# Altium schematic sheet Main.SchDoc(Main)
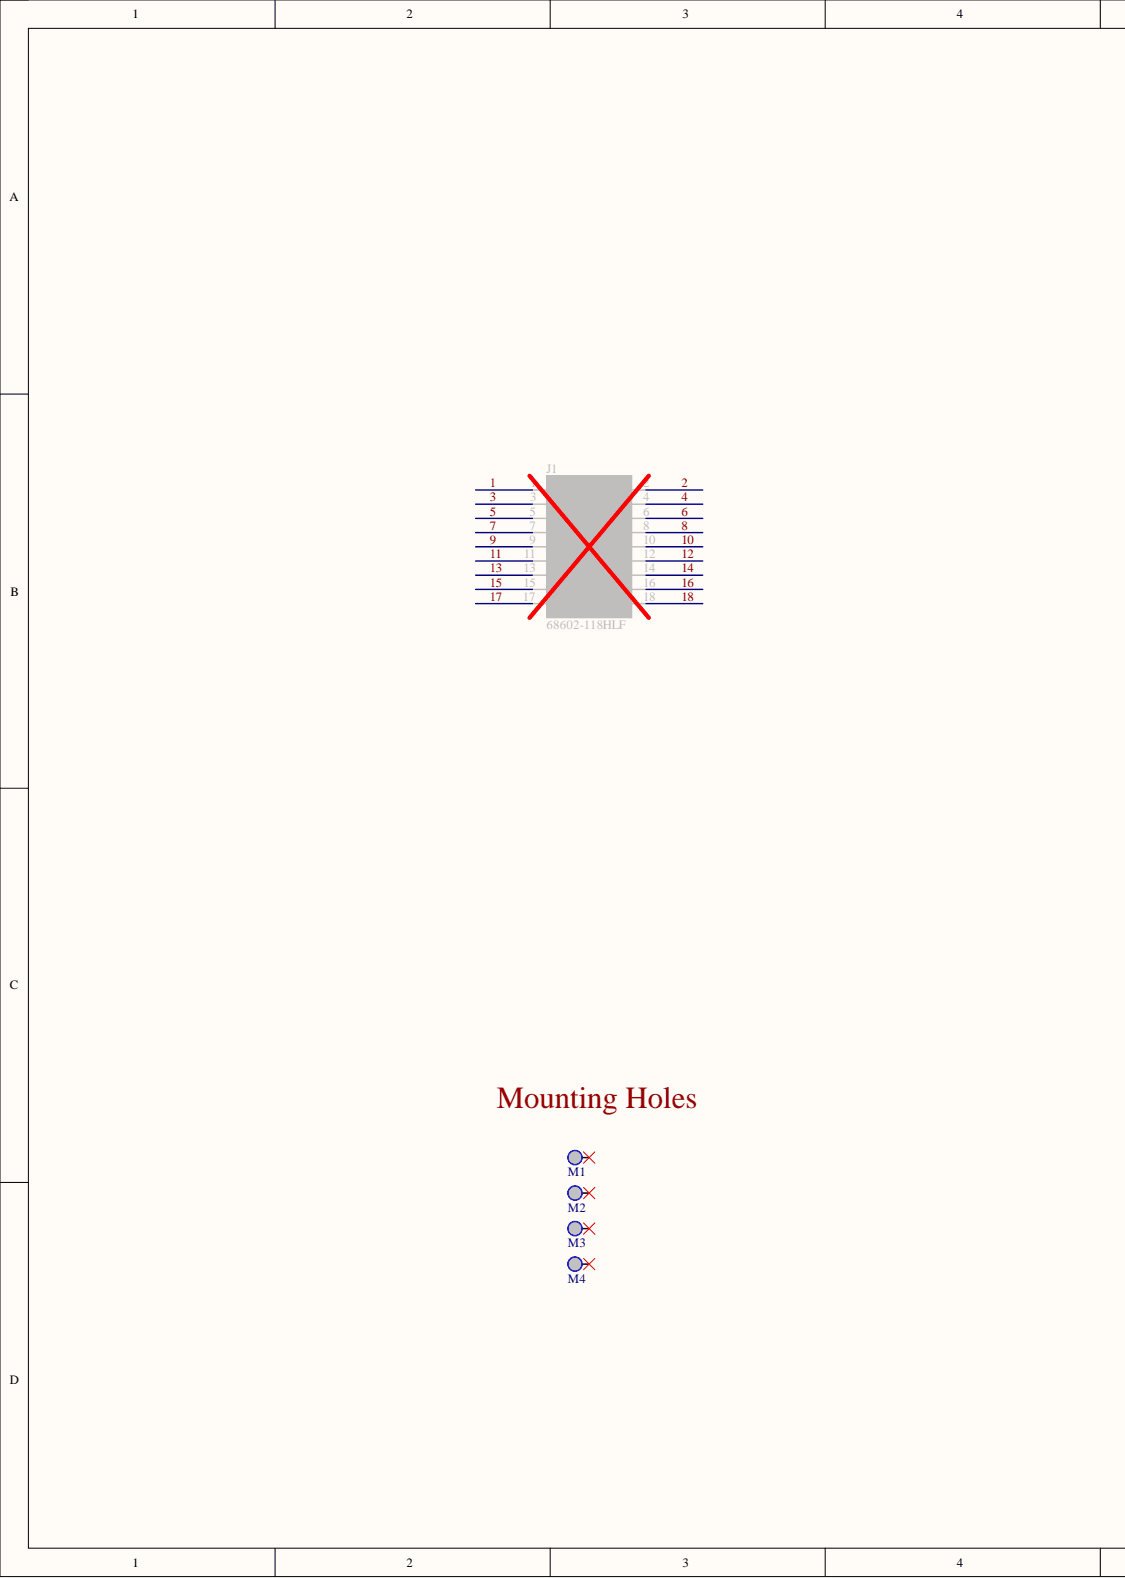
[diagram p0: Main.SchDoc, left]
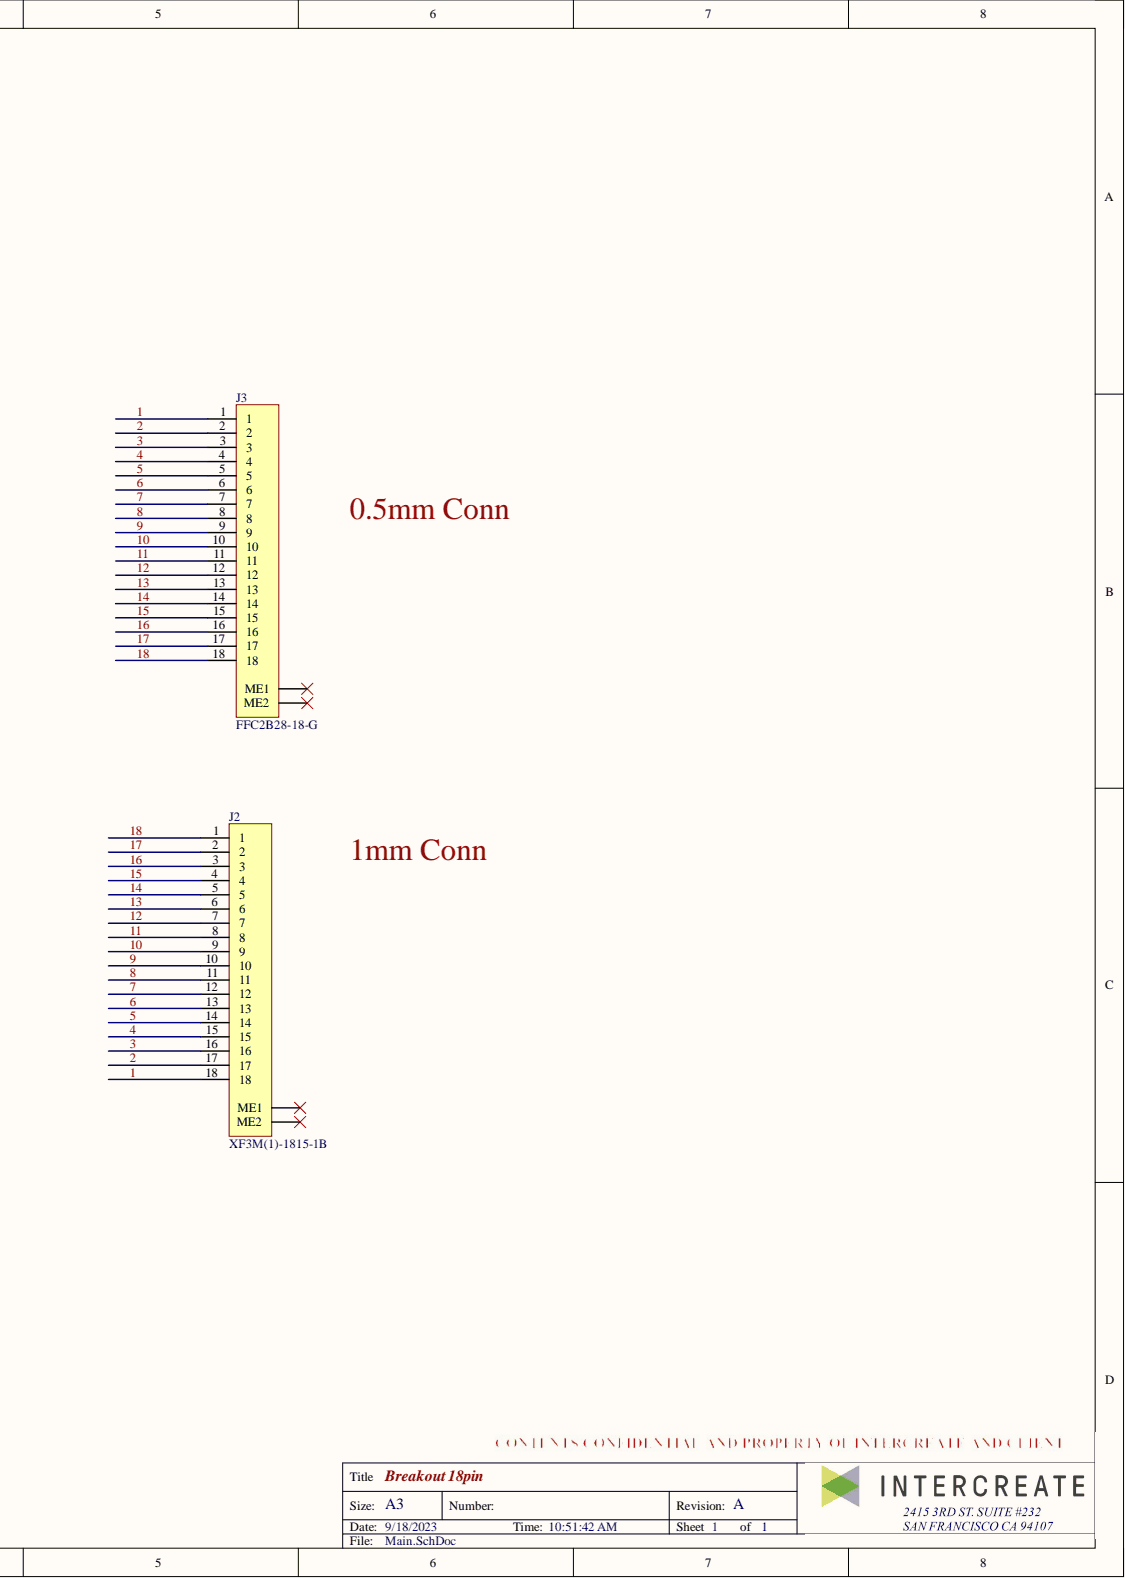
[diagram p1: Main.SchDoc, right]

## Parts
J1  68602-118HLF  [HDR-V-2X9-2.54MM]
J2  XF3M(1)-1815-1B  [CONN-FFC-H-18P-1MM]
J3  FFC2B28-18-G  [CONN-FFC-H-18P-0.5MM]
M1  MTG_HOLE  [MH_P_2.5MM]  (value not drawn)  (no BOM)
M2  MTG_HOLE  [MH_P_2.5MM]  (value not drawn)  (no BOM)
M3  MTG_HOLE  [MH_P_2.5MM]  (value not drawn)  (no BOM)
M4  MTG_HOLE  [MH_P_2.5MM]  (value not drawn)  (no BOM)

## Nets
1: J1.1 J2.18 J3.1
2: J1.2 J2.17 J3.2
3: J1.3 J2.16 J3.3
4: J1.4 J2.15 J3.4
5: J1.5 J2.14 J3.5
6: J1.6 J2.13 J3.6
7: J1.7 J2.12 J3.7
8: J1.8 J2.11 J3.8
9: J1.9 J2.10 J3.9
10: J1.10 J2.9 J3.10
11: J1.11 J2.8 J3.11
12: J1.12 J2.7 J3.12
13: J1.13 J2.6 J3.13
14: J1.14 J2.5 J3.14
15: J1.15 J2.4 J3.15
16: J1.16 J2.3 J3.16
17: J1.17 J2.2 J3.17
18: J1.18 J2.1 J3.18

Not connected: J2 ME1 ME2; J3 ME1 ME2; M1 1; M2 1; M3 1; M4 1

## Library data of the parts
J1 = HDR-V-2X9-M-2.54MM: Conn Unshrouded Header HDR 18 POS 2.54mm Solder ST Top Entry Thru-Hole BergStik® Bulk; Manufacturer 1: Amphenol ICC / FCI; Contact Post Length: 3.81mm; Current Rating: 3A; Gender: Male; Insulation Resistance: 5GR; Max Voltage Rating (AC): 1.5kV; Number of Contacts: 18; Row Spacing: 0.1inch; Category: Connectors; Color: Black; Component Kind: Standard; ComponentLink1Description: https://datasheet.ciiva.com/5168/67996-5168806.pdf?src-supplier=Mouser; ComponentLink1URL: https://datasheet.ciiva.com/5168/67996-5168806.pdf?src-supplier=Mouser; ComponentLink2Description: https://datasheet.ciiva.com/10135/67996-10135126.pdf?src-supplier=Avnet; ComponentLink2URL: https://datasheet.ciiva.com/10135/67996-10135126.pdf?src-supplier=Avnet; Contact Gender: Male; Contact Material: Bronze; Contact Mating Length: 0.23inch; Contact Plating: Gold,GXT; Contact Type: Male Pin; Depth: 4.83mm; Flammability Rating: UL94 V-0; Housing Color: Black; Housing Material: Thermoplastic; Length: 22.86mm; Manufacturer Part Number 1: 68602-118HLF; Max Operating Temperature: 125°C; Min Operating Temperature: -65°C; Mounting Technology: Through Hole; Number of Positions: 18; Number of Rows: 2; Orientation: Straight; Package Quantity: 400; Packaging: Bulk; Pitch: 2.54mm; Pricing: 1=0.1296 (USD); Radiation Hardening: No; RoHS: Yes; Series: BERGSTIK® II, MezzSelect™, Basics+; Stock: 380; Supplier: Arrow Electronics; Supplier Part Number: 68602-118HLF; Termination: Solder; Voltage Rating: 1.5kV; Library Name: intercreate.IntLib
J2 = CONN-FFC-H-18P-1MM: FFC & FPC Connectors RotaryBackLock 1.0mm Dual Contact 18pin; Manufacturer 1: Omron; Actuator Color: Black; Actuator Material: Polymer,Liquid Crystal Polymer; Contact Resistance: 50mR; Current Rating: 500mA; Insulation Resistance: 100MR; Mating Cycles: 20; Max Voltage Rating (DC): 5.05kV; Number of Contacts: 18; Pitch: 1mm; Voltage Rating: 50V; Category: FFC / FPC; Component Kind: Standard; ComponentLink1Description: https://datasheet.ciiva.com/29758/xf3m-0813-29758486.pdf?src-supplier=Digi-Key; ComponentLink1URL: https://datasheet.ciiva.com/29758/xf3m-0813-29758486.pdf?src-supplier=Digi-Key; ComponentLink2Description: https://datasheet.ciiva.com/29758/xf3m-0813-29758490.pdf?src-supplier=Digi-Key; ComponentLink2URL: https://datasheet.ciiva.com/29758/xf3m-0813-29758490.pdf?src-supplier=Digi-Key; Contact Location: Dual; Contact Material: Copper Alloy; Contact Plating: Gold; Flammability Rating: UL94 V-0; Housing Color: Natural; Housing Material: Polymer; Manufacturer Part Number 1: XF3M(1)-1815-1B; Max Current Rating: 500mA; Max Operating Temperature: 85°C; Min Operating Temperature: -30°C; Mounting Technology: Surface Mount; Number of Positions: 18; Number of Rows: 1; Orientation: Right Angle; Package Quantity: 1500; Packaging: Tape and Reel; Pricing: 1500=1.15 (USD); RoHS: Yes; RoHS Compliant: Yes; Series: XF3M; Stock: 740; Supplier: Newark; Supplier Part Number: 40AJ2874; Termination: Solder; Library Name: intercreate.IntLib
J3 = CONN-FFC-H-18P-0.5MM: 18W, 0.5MM Ffc Con, R/a, Dual Cont,; Manufacturer 1: Global Connector Technology; Category: Connectors; Component Kind: Standard; Manufacturer Part Number 1: FFC2B28-18-G; Pricing: 1=0.207, 10=0.207, 25=0.207, 50=0.207, 100=0.207, 250=0.207, 500=0.207 (USD); RoHS: No; Stock: 3153; Supplier: Newark; Supplier Part Number: 49AJ2853; Library Name: intercreate.IntLib
M1-M4 = MTG_HOLE: MTG HOLE PLATED VARIOUS SIZES; Library Name: intercreate.IntLib
